# Altium schematic sheet 03 - DDR3.SchDoc("03 - DDR3")
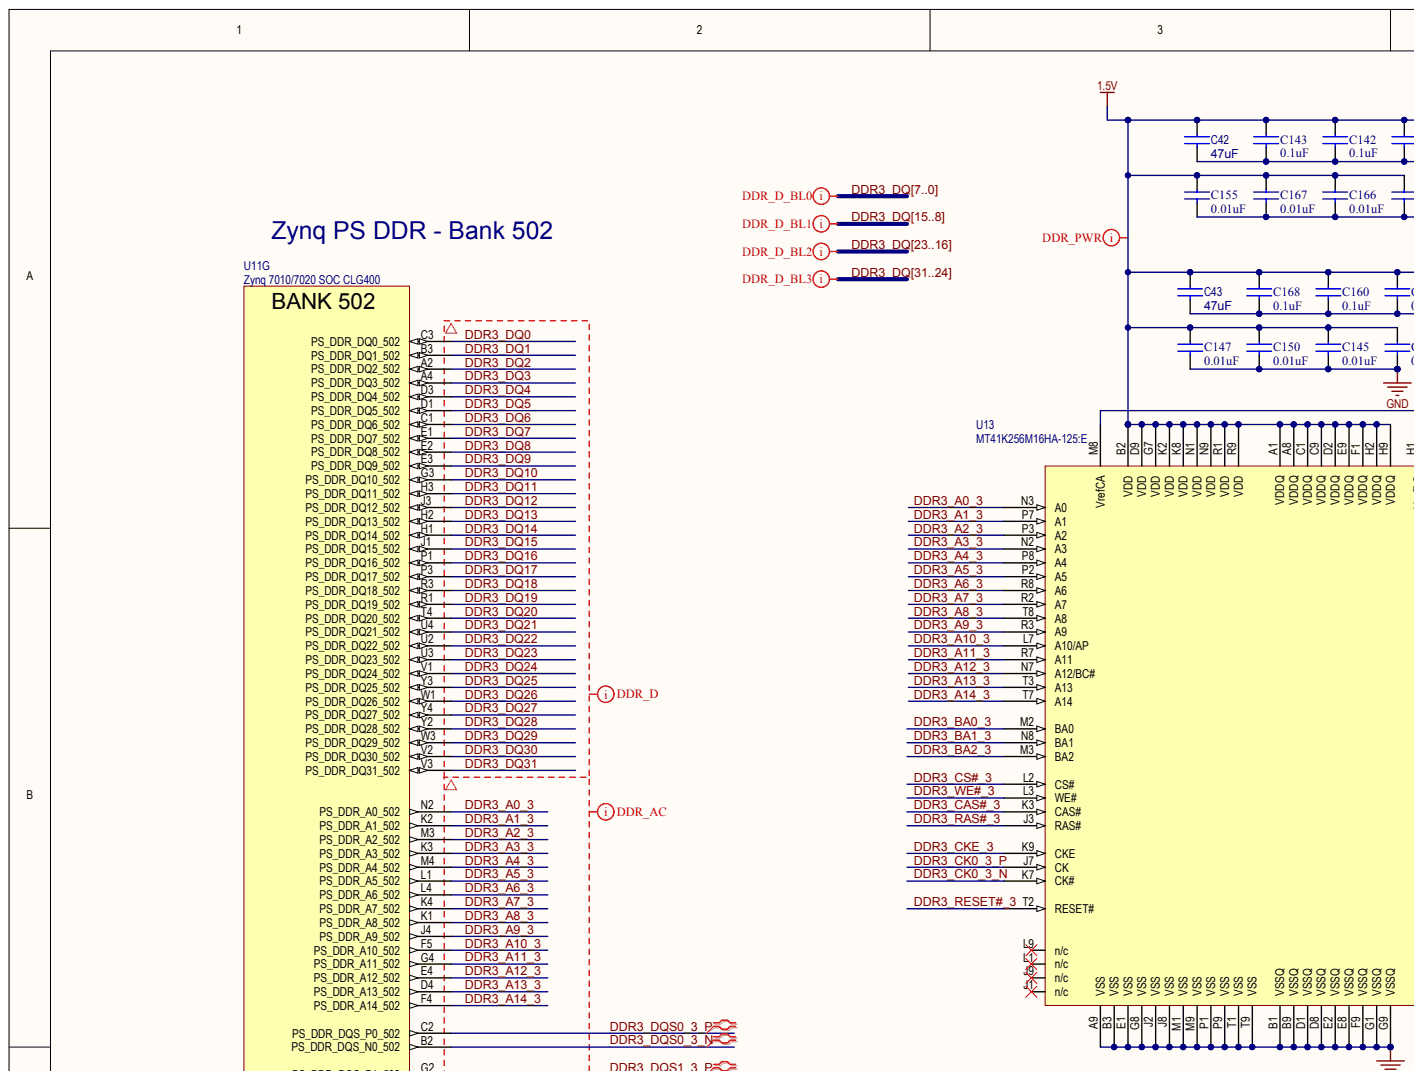
[diagram p0: 03 - DDR3.SchDoc, top left]
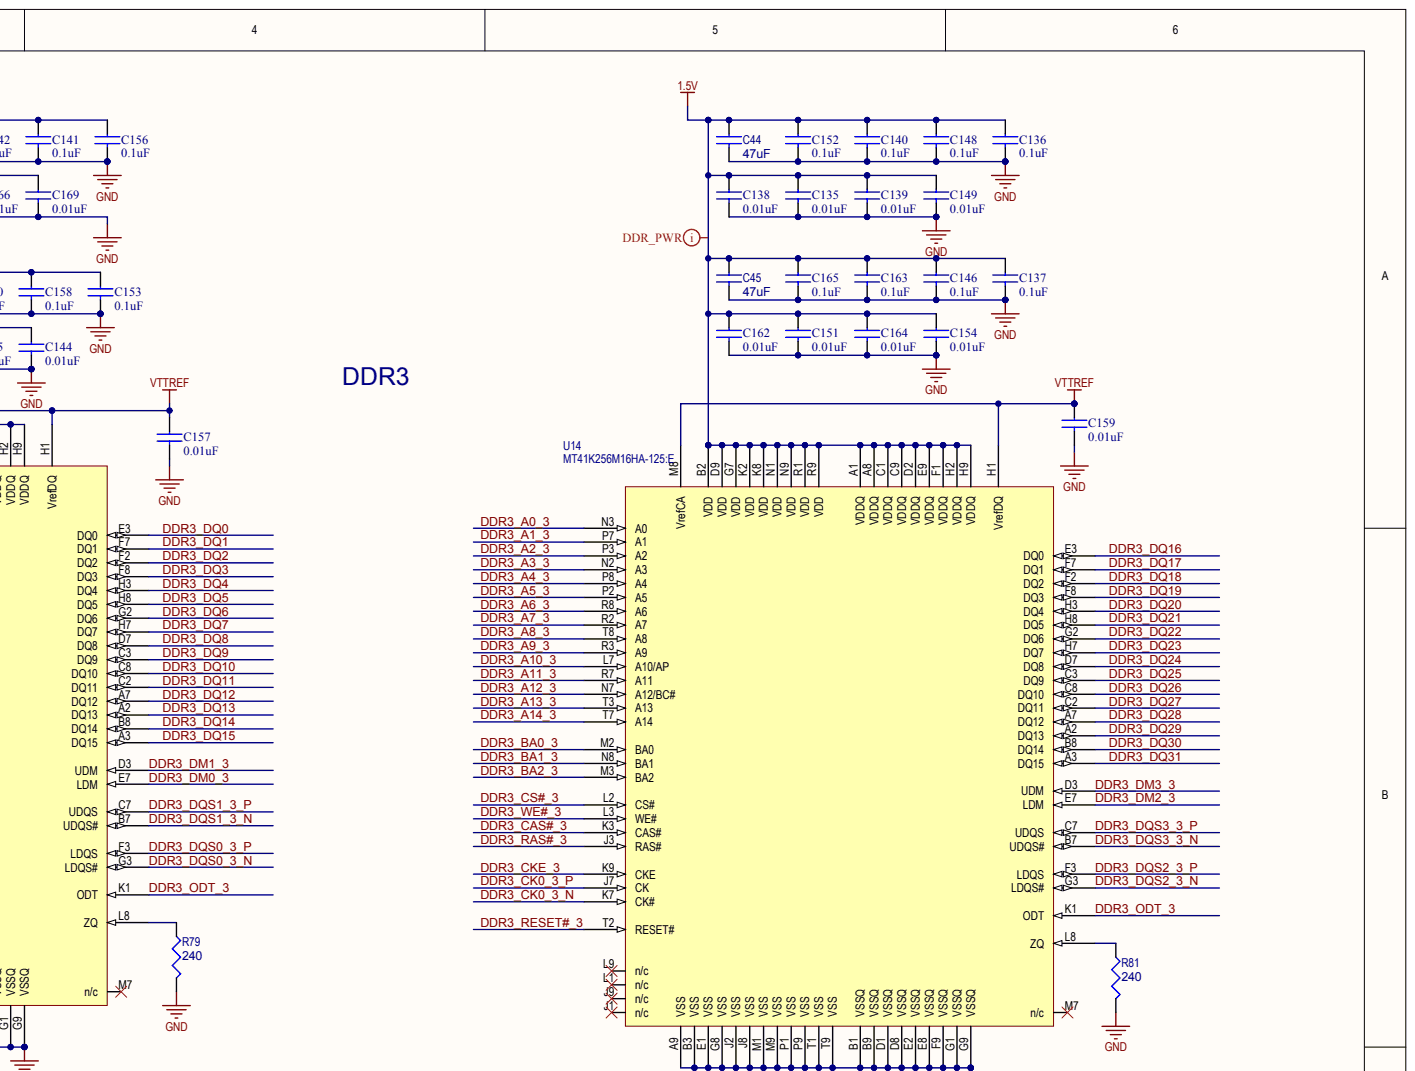
[diagram p1: 03 - DDR3.SchDoc, top right]
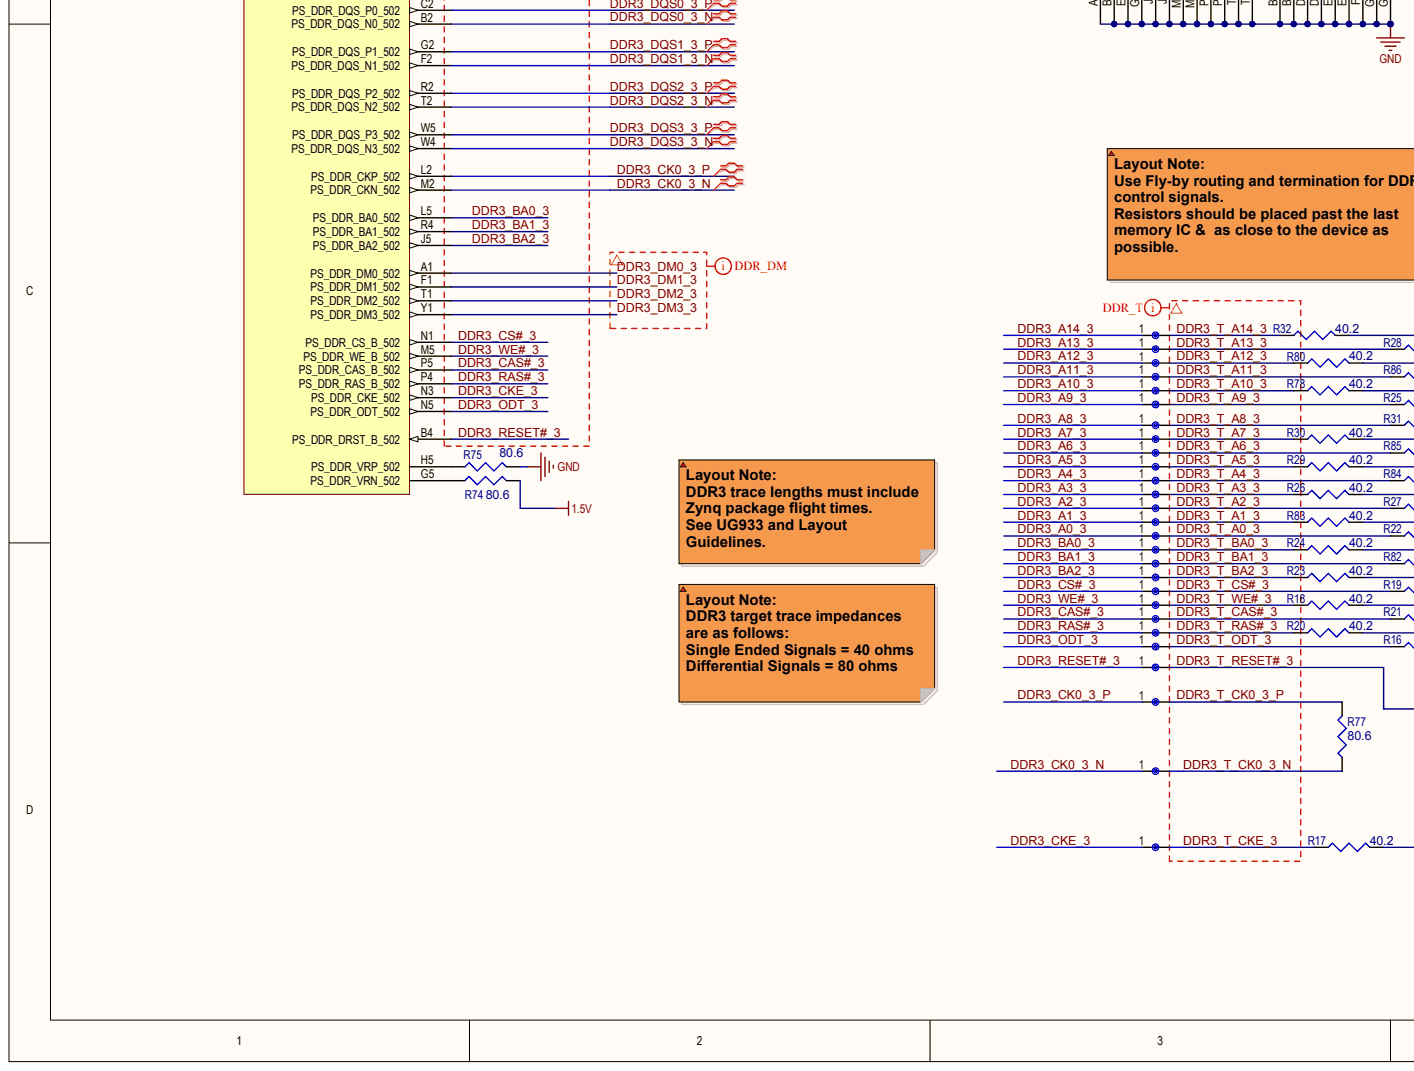
[diagram p2: 03 - DDR3.SchDoc, bottom left]
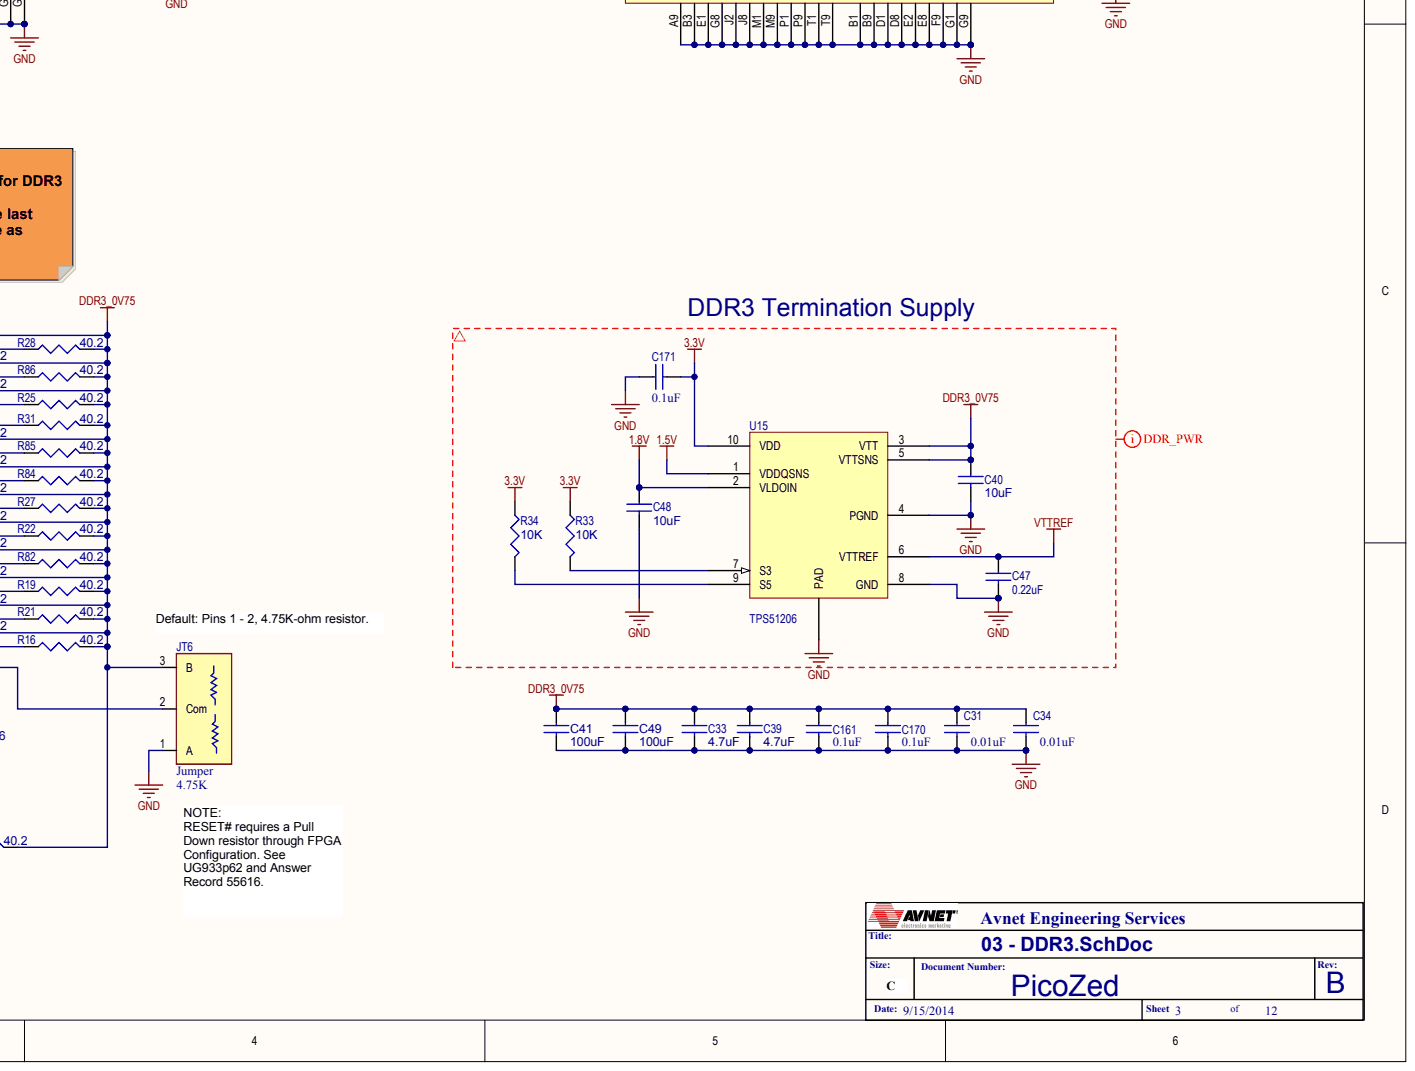
[diagram p3: 03 - DDR3.SchDoc, bottom right]

## Parts
C31  value 0.01uF  (hidden comment: PC003)
C33  4.7uF
C34  value 0.01uF  (hidden comment: PC003)
C39  4.7uF
C40  10uF
C41  100uF
C42  47uF
C43  47uF
C44  47uF
C45  47uF
C47  value 0.22uF  (hidden comment: PC231)
C48  10uF
C49  100uF
C135  value 0.01uF  (hidden comment: PC003)
C136  value 0.1uF  (hidden comment: PC522)
C137  value 0.1uF  (hidden comment: PC522)
C138  value 0.01uF  (hidden comment: PC003)
C139  value 0.01uF  (hidden comment: PC003)
C140  value 0.1uF  (hidden comment: PC522)
C141  value 0.1uF  (hidden comment: PC522)
C142  value 0.1uF  (hidden comment: PC522)
C143  value 0.1uF  (hidden comment: PC522)
C144  value 0.01uF  (hidden comment: PC003)
C145  value 0.01uF  (hidden comment: PC003)
C146  value 0.1uF  (hidden comment: PC522)
C147  value 0.01uF  (hidden comment: PC003)
C148  value 0.1uF  (hidden comment: PC522)
C149  value 0.01uF  (hidden comment: PC003)
C150  value 0.01uF  (hidden comment: PC003)
C151  value 0.01uF  (hidden comment: PC003)
C152  value 0.1uF  (hidden comment: PC522)
C153  value 0.1uF  (hidden comment: PC522)
C154  value 0.01uF  (hidden comment: PC003)
C155  value 0.01uF  (hidden comment: PC003)
C156  value 0.1uF  (hidden comment: PC522)
C157  value 0.01uF  (hidden comment: PC003)
C158  value 0.1uF  (hidden comment: PC522)
C159  value 0.01uF  (hidden comment: PC003)
C160  value 0.1uF  (hidden comment: PC522)
C161  value 0.1uF  (hidden comment: PC522)
C162  value 0.01uF  (hidden comment: PC003)
C163  value 0.1uF  (hidden comment: PC522)
C164  value 0.01uF  (hidden comment: PC003)
C165  value 0.1uF  (hidden comment: PC522)
C166  value 0.01uF  (hidden comment: PC003)
C167  value 0.01uF  (hidden comment: PC003)
C168  value 0.1uF  (hidden comment: PC522)
C169  value 0.01uF  (hidden comment: PC003)
C170  value 0.1uF  (hidden comment: PC522)
C171  value 0.1uF  (hidden comment: PC522)
JT6  value 4.75K  Device: Jumper
NT1  PO030  (value not drawn)
NT2  PO030  (value not drawn)
NT3  PO030  (value not drawn)
NT4  PO030  (value not drawn)
NT5  PO030  (value not drawn)
NT6  PO030  (value not drawn)
NT7  PO030  (value not drawn)
NT8  PO030  (value not drawn)
NT9  PO030  (value not drawn)
NT10  PO030  (value not drawn)
NT11  PO030  (value not drawn)
NT12  PO030  (value not drawn)
NT13  PO030  (value not drawn)
NT14  PO030  (value not drawn)
NT15  PO030  (value not drawn)
NT16  PO030  (value not drawn)
NT17  PO030  (value not drawn)
NT18  PO030  (value not drawn)
NT19  PO030  (value not drawn)
NT20  PO030  (value not drawn)
NT21  PO030  (value not drawn)
NT22  PO030  (value not drawn)
NT23  PO030  (value not drawn)
NT24  PO030  (value not drawn)
NT25  PO030  (value not drawn)
NT26  PO030  (value not drawn)
NT27  PO030  (value not drawn)
R16  40.2
R17  40.2
R18  40.2
R19  40.2
R20  40.2
R21  40.2
R22  40.2
R23  40.2
R24  40.2
R25  40.2
R26  40.2
R27  40.2
R28  40.2
R29  40.2
R30  40.2
R31  40.2
R32  40.2
R33  10K
R34  10K
R74  80.6
R75  80.6
R77  80.6
R78  40.2
R79  240
R80  40.2
R81  240
R82  40.2
R83  40.2
R84  40.2
R85  40.2
R86  40.2
U11  Zynq 7010/7020 SOC CLG400  (part G)
U13  MT41K256M16HA-125:E
U14  MT41K256M16HA-125:E
U15  TPS51206

## Nets
1.5V: C42.1 C43.1 C44.1 C45.1 C135.1 C136.1 C137.1 C138.1 C139.1 C140.1 C141.1 C142.1 C143.1 C144.1 C145.1 C146.1 C147.1 C148.1 C149.1 C150.1 C151.1 C152.1 C153.1 C154.1 C155.1 C156.1 C158.1 C160.1 C162.1 C163.1 C164.1 C165.1 C166.1 C167.1 C168.1 C169.1 R74.2 U13.A1 U13.A8 U13.B2 U13.C1 U13.C9 U13.D2 U13.D9 U13.E9 U13.F1 U13.G7 U13.H2 U13.H9 U13.K2 U13.K8 U13.N1 U13.N9 U13.R1 U13.R9 U14.A1 U14.A8 U14.B2 U14.C1 U14.C9 U14.D2 U14.D9 U14.E9 U14.F1 U14.G7 U14.H2 U14.H9 U14.K2 U14.K8 U14.N1 U14.N9 U14.R1 U14.R9 U15.1
1.8V: C48.1 U15.2
3.3V: C171.2 R33.1 R34.1 U15.10
DDR3_0V75: C31.1 C33.1 C34.1 C39.1 C40.1 C41.1 C49.1 C161.1 C170.1 JT6.3 R16.2 R17.2 R18.2 R19.2 R20.2 R21.2 R22.2 R23.2 R24.2 R25.2 R26.2 R27.2 R28.2 R29.2 R30.2 R31.2 R32.2 R78.2 R80.2 R82.2 R83.2 R84.2 R85.2 R86.2 U15.3 U15.5
GND: C31.2 C33.2 C34.2 C39.2 C40.2 C41.2 C42.2 C43.2 C44.2 C45.2 C47.2 C48.2 C49.2 C135.2 C136.2 C137.2 C138.2 C139.2 C140.2 C141.2 C142.2 C143.2 C144.2 C145.2 C146.2 C147.2 C148.2 C149.2 C150.2 C151.2 C152.2 C153.2 C154.2 C155.2 C156.2 C157.2 C158.2 C159.2 C160.2 C161.2 C162.2 C163.2 C164.2 C165.2 C166.2 C167.2 C168.2 C169.2 C170.2 C171.1 JT6.1 R75.2 R79.2 R81.2 U13.A9 U13.B1 U13.B3 U13.B9 U13.D1 U13.D8 U13.E1 U13.E2 U13.E8 U13.F9 U13.G1 U13.G8 U13.G9 U13.J2 U13.J8 U13.M1 U13.M9 U13.P1 U13.P9 U13.T1 U13.T9 U14.A9 U14.B1 U14.B3 U14.B9 U14.D1 U14.D8 U14.E1 U14.E2 U14.E8 U14.F9 U14.G1 U14.G8 U14.G9 U14.J2 U14.J8 U14.M1 U14.M9 U14.P1 U14.P9 U14.T1 U14.T9 U15.4 U15.8 U15.11
VTTREF: C47.1 C157.1 C159.1 U13.H1 U13.M8 U14.H1 U14.M8 U15.6
DDR3_A0_3: NT10.1 U11.N2 U13.N3 U14.N3  [also labelled DDR3_A0]
DDR3_A1_3: NT13.1 U11.K2 U13.P7 U14.P7  [also labelled DDR3_A1]
DDR3_A2_3: NT14.1 U11.M3 U13.P3 U14.P3  [also labelled DDR3_A2]
DDR3_A3_3: NT15.1 U11.K3 U13.N2 U14.N2  [also labelled DDR3_A3]
DDR3_A4_3: NT21.1 U11.M4 U13.P8 U14.P8  [also labelled DDR3_A4]
DDR3_A5_3: NT17.1 U11.L1 U13.P2 U14.P2  [also labelled DDR3_A5]
DDR3_A6_3: NT22.1 U11.L4 U13.R8 U14.R8  [also labelled DDR3_A6]
DDR3_A7_3: NT18.1 U11.K4 U13.R2 U14.R2  [also labelled DDR3_A7]
DDR3_A8_3: NT23.1 U11.K1 U13.T8 U14.T8  [also labelled DDR3_A8]
DDR3_A9_3: NT19.1 U11.J4 U13.R3 U14.R3  [also labelled DDR3_A9]
DDR3_A10_3: NT16.1 U11.F5 U13.L7 U14.L7  [also labelled DDR3_A10]
DDR3_A11_3: NT24.1 U11.G4 U13.R7 U14.R7  [also labelled DDR3_A11]
DDR3_A12_3: NT25.1 U11.E4 U13.N7 U14.N7  [also labelled DDR3_A12]
DDR3_A13_3: NT26.1 U11.D4 U13.T3 U14.T3  [also labelled DDR3_A13]
DDR3_A14_3: NT27.1 U11.F4 U13.T7 U14.T7  [also labelled DDR3_A14]
DDR3_BA0_3: NT9.1 U11.L5 U13.M2 U14.M2  [also labelled DDR3_BA0]
DDR3_BA1_3: NT20.1 U11.R4 U13.N8 U14.N8  [also labelled DDR3_BA1]
DDR3_BA2_3: NT8.1 U11.J5 U13.M3 U14.M3  [also labelled DDR3_BA2]
DDR3_CAS#_3: NT5.1 U11.P5 U13.K3 U14.K3  [also labelled DDR3_CAS#]
DDR3_CK0_3_N: NT1.1 U11.M2 U13.K7 U14.K7  [also labelled DDR3_CK0_N]
DDR3_CK0_3_P: NT2.1 U11.L2 U13.J7 U14.J7  [also labelled DDR3_CK0_P]
DDR3_CKE_3: NT11.1 U11.N3 U13.K9 U14.K9  [also labelled DDR3_CKE]
DDR3_CS#_3: NT7.1 U11.N1 U13.L2 U14.L2  [also labelled DDR3_CS#]
DDR3_DM0_3: U11.A1 U13.E7  [also labelled DDR3_DM0]
DDR3_DM1_3: U11.F1 U13.D3  [also labelled DDR3_DM1]
DDR3_DM2_3: U11.T1 U14.E7  [also labelled DDR3_DM2]
DDR3_DM3_3: U11.Y1 U14.D3  [also labelled DDR3_DM3]
DDR3_DQ0: U11.C3 U13.E3
DDR3_DQ1: U11.B3 U13.F7
DDR3_DQ2: U11.A2 U13.F2
DDR3_DQ3: U11.A4 U13.F8
DDR3_DQ4: U11.D3 U13.H3
DDR3_DQ5: U11.D1 U13.H8
DDR3_DQ6: U11.C1 U13.G2
DDR3_DQ7: U11.E1 U13.H7
DDR3_DQ8: U11.E2 U13.D7
DDR3_DQ9: U11.E3 U13.C3
DDR3_DQ10: U11.G3 U13.C8
DDR3_DQ11: U11.H3 U13.C2
DDR3_DQ12: U11.J3 U13.A7
DDR3_DQ13: U11.H2 U13.A2
DDR3_DQ14: U11.H1 U13.B8
DDR3_DQ15: U11.J1 U13.A3
DDR3_DQ16: U11.P1 U14.E3
DDR3_DQ17: U11.P3 U14.F7
DDR3_DQ18: U11.R3 U14.F2
DDR3_DQ19: U11.R1 U14.F8
DDR3_DQ20: U11.T4 U14.H3
DDR3_DQ21: U11.U4 U14.H8
DDR3_DQ22: U11.U2 U14.G2
DDR3_DQ23: U11.U3 U14.H7
DDR3_DQ24: U11.V1 U14.D7
DDR3_DQ25: U11.Y3 U14.C3
DDR3_DQ26: U11.W1 U14.C8
DDR3_DQ27: U11.Y4 U14.C2
DDR3_DQ28: U11.Y2 U14.A7
DDR3_DQ29: U11.W3 U14.A2
DDR3_DQ30: U11.V2 U14.B8
DDR3_DQ31: U11.V3 U14.A3
DDR3_DQS0_3_N: U11.B2 U13.G3  [also labelled DDR3_DQS0_N]
DDR3_DQS0_3_P: U11.C2 U13.F3  [also labelled DDR3_DQS0_P]
DDR3_DQS1_3_N: U11.F2 U13.B7  [also labelled DDR3_DQS1_N]
DDR3_DQS1_3_P: U11.G2 U13.C7  [also labelled DDR3_DQS1_P]
DDR3_DQS2_3_N: U11.T2 U14.G3  [also labelled DDR3_DQS2_N]
DDR3_DQS2_3_P: U11.R2 U14.F3  [also labelled DDR3_DQS2_P]
DDR3_DQS3_3_N: U11.W4 U14.B7  [also labelled DDR3_DQS3_N]
DDR3_DQS3_3_P: U11.W5 U14.C7  [also labelled DDR3_DQS3_P]
DDR3_ODT_3: NT4.1 U11.N5 U13.K1 U14.K1  [also labelled DDR3_ODT]
DDR3_RAS#_3: NT3.1 U11.P4 U13.J3 U14.J3  [also labelled DDR3_RAS#]
DDR3_RESET#_3: NT12.1 U11.B4 U13.T2 U14.T2  [also labelled DDR3_RESET#]
DDR3_T_A0_3: NT10.2 R22.1  [also labelled DDR3_T_A0]
DDR3_T_A1_3: NT13.2 R83.1  [also labelled DDR3_T_A1]
DDR3_T_A2_3: NT14.2 R27.1  [also labelled DDR3_T_A2]
DDR3_T_A3_3: NT15.2 R26.1  [also labelled DDR3_T_A3]
DDR3_T_A4_3: NT21.2 R84.1  [also labelled DDR3_T_A4]
DDR3_T_A5_3: NT17.2 R29.1  [also labelled DDR3_T_A5]
DDR3_T_A6_3: NT22.2 R85.1  [also labelled DDR3_T_A6]
DDR3_T_A7_3: NT18.2 R30.1  [also labelled DDR3_T_A7]
DDR3_T_A8_3: NT23.2 R31.1  [also labelled DDR3_T_A8]
DDR3_T_A9_3: NT19.2 R25.1  [also labelled DDR3_T_A9]
DDR3_T_A10_3: NT16.2 R78.1  [also labelled DDR3_T_A10]
DDR3_T_A11_3: NT24.2 R86.1  [also labelled DDR3_T_A11]
DDR3_T_A12_3: NT25.2 R80.1  [also labelled DDR3_T_A12]
DDR3_T_A13_3: NT26.2 R28.1  [also labelled DDR3_T_A13]
DDR3_T_A14_3: NT27.2 R32.1  [also labelled DDR3_T_A14]
DDR3_T_BA0_3: NT9.2 R24.1  [also labelled DDR3_T_BA0]
DDR3_T_BA1_3: NT20.2 R82.1  [also labelled DDR3_T_BA1]
DDR3_T_BA2_3: NT8.2 R23.1  [also labelled DDR3_T_BA2]
DDR3_T_CAS#_3: NT5.2 R21.1  [also labelled DDR3_T_CAS#]
DDR3_T_CK0_3_N: NT1.2 R77.1  [also labelled DDR3_T_CK0_N]
DDR3_T_CK0_3_P: NT2.2 R77.2  [also labelled DDR3_T_CK0_P]
DDR3_T_CKE_3: NT11.2 R17.1  [also labelled DDR3_T_CKE]
DDR3_T_CS#_3: NT7.2 R19.1  [also labelled DDR3_T_CS#]
DDR3_T_ODT_3: NT4.2 R16.1  [also labelled DDR3_T_ODT]
DDR3_T_RAS#_3: NT3.2 R20.1  [also labelled DDR3_T_RAS#]
DDR3_T_RESET#_3: JT6.2 NT12.2  [also labelled DDR3_T_RESET#]
DDR3_T_WE#_3: NT6.2 R18.1  [also labelled DDR3_T_WE#]
DDR3_WE#_3: NT6.1 U11.M5 U13.L3 U14.L3  [also labelled DDR3_WE#]
NetR33_2: R33.2 U15.7
NetR34_2: R34.2 U15.9
NetR74_1: R74.1 U11.G5
NetR75_1: R75.1 U11.H5
NetR79_1: R79.1 U13.L8
NetR81_1: R81.1 U14.L8
N00120:
N00121:
N00122:
N00123:
N00124:

Not connected: U13 J1 J9 L1 L9 M7; U14 J1 J9 L1 L9 M7

## Library data of the parts
C31 C34 C135 C138 C139 C144 C145 C147 C149-C151 C154 C155 C157 C159 C162 C164 C166 C167 C169 = (no symbol name): PartCode: PC; AVLNo: AVPC-0000003; PkgType: SM; Volt: 10V; Tol: 10%; Dielectric: X5R; ESR: CAP; MFG Code: AVX; MFGPN: 0201ZD103KAT2A; AsyTxt: PC003; RoHS: Yes; Status: Preliminary; Device: CAP; ComponentLink1Description: Datasheet; ComponentLink2Description: MFR Website; ComponentLink2URL: www.avx.com; ComponentLink1URL: C:\AVDBLIB\DATASHEETS\AVX_CX5R.pdf
  C135 C138 C139 C149 C151 C154 C159 C162 C164: CLASSNAME: U4-BYP
  C144 C145 C147 C150 C155 C157 C166 C167 C169: CLASSNAME: U3-BYP
C33 C39 = (no symbol name): PartCode: PC; AVLNo: AVPC-0000499; PkgType: SM; AsyTxt: PC499; Volt: 6.3V; Tol: 20%; Dielectric: X5R; MFG Code: AVX; MFGPN: GRM155R60J475ME87D; Power: 0; Device: CAP; Value: 4.7uF; RoHS: Yes; ComponentLink1Description: Datasheet; Availability: 140K-DK; Cost: .46; Size: 1.9; Status: Preliminary; Received: 0; CLASSNAME: DDR_TERM; ComponentLink1URL: C:\AVDBLIB\DATASHEETS\murata_caps_c02e.pdf
C40 C48 = (no symbol name): PartCode: PC; AVLNo: AVPC-0000086; PkgType: SM; AsyTxt: PC086; Volt: 6.3V; Tol: 20%; Dielectric: X5R; MFG Code: AVX; MFGPN: 06036D106MAT2A; Power: 0; Device: CAP; Value: 10uF; RoHS: Yes; ComponentLink1Description: Datasheet; Availability: 2M-AV; Cost: .13; Size: 3.4; Status: Preliminary; Received: 0; CLASSNAME: DDR_TERM; ComponentLink1URL: C:\AVDBLIB\DATASHEETS\AVX_CCOG.pdf
C41 C49 = (no symbol name): PartCode: PC; AVLNo: AVPC-0000209; PkgType: SM; AsyTxt: PC209; Volt: 6.3V; Tol: 20%; Dielectric: X5R; MFG Code: MUR; MFGPN: GRM32EF50J107ZE20K; Power: 0; Device: CAP; Value: 100uF; RoHS: Yes; ComponentLink1Description: Datasheet; Availability: 180K-AV; Cost: .41; Size: 9.11; Status: Preliminary; Received: 0; CLASSNAME: DDR_TERM; ComponentLink1URL: C:\AVDBLIB\DATASHEETS\GRM31CR60J107ME39.pdf
C42-C45 = (no symbol name): PartCode: PC; AVLNo: AVPC-0000521; PkgType: SM; Volt: 6.3V; Tol: 20%; Dielectric: X5R; MFG Code: TYC; MFGPN: JMK212BJ476MG-T; Power: 0; AsyTxt: PC521; Device: CAP; Value: 47uF; RoHS: Yes; ComponentLink1Description: Datasheet; Availability: 100K-AV; Cost: .18; Size: 5.42; Status: Preliminary; Received: 0; ComponentLink1URL: C:\AVDBLIB\DATASHEETS\TYC_Caps.pdf
  C42 C43: CLASSNAME: U3-BYP
  C44 C45: CLASSNAME: U4-BYP
C47 = (no symbol name): PartCode: PC; AVLNo: AVPC-0000231; PkgType: SM; Volt: 6.3V; Tol: 10%; Dielectric: X5R; ESR: CAP; MFG Code: AVX; MFGPN: 04026D224KAT2A; AsyTxt: PC231; RoHS: Yes; Status: Preliminary; Device: CAP; ComponentLink1Description: Datasheet; ComponentLink2Description: MFR Website; ComponentLink2URL: www.avx.com; ComponentLink1URL: C:\AVDBLIB\DATASHEETS\AVX_CX5R.pdf
C136 C137 C140 C146 C148 C152 C161 C163 C165 C170 C171 = (no symbol name): PartCode: PC; MFGPN: 02016D104KAT2A; AVLNo: AVPC-0000522; PkgType: SM; Volt: 10V; Tol: 10%; Dielectric: X5R; Device: CAP; MFG Code: AVX; Status: Preliminary; AsyTxt: PC522; RoHS: Yes; ComponentLink1Description: Datasheet; ComponentLink1URL: C:\AVDBLIB\DATASHEETS\AVX_CCOG.pdf
  C136 C137 C140 C146 C148 C152 C163 C165: CLASSNAME: U4-BYP
C141-C143 C153 C156 C158 C160 C168 = (no symbol name): PartCode: PC; AVLNo: AVPC-0000522; PkgType: SM; Volt: 10V; Tol: 10%; Dielectric: X5R; Device: CAP; MFG Code: AVX; MFGPN: 02016D104KAT2A; AsyTxt: PC522; RoHS: Yes; Status: Preliminary; ComponentLink1Description: Datasheet; CLASSNAME: U3-BYP; ComponentLink1URL: C:\AVDBLIB\DATASHEETS\AVX_CCOG.pdf
JT6 = (no symbol name): PartCode: PO; PkgType: SM; Device Type: 3-pad 4K75 0402; MFG Code: DAL; MFGPN: CRCW04024K75FKED; Note: Place Pads: 1-2; RoHS: Yes; Status: Preliminary; ComponentLink1Description: Datasheet; ComponentLink1URL: C:\AVDBLIB\DATASHEETS\Dale_CRCW.pd
NT1-NT27 = (no symbol name): PartCode: PO; AVLNo: AVPO-0000030; Device: Net Tie; PkgType: SM; MFG Code: None; AsyTxt: PO030; RoHS: Yes; Status: Preliminary; Device Type: 2 Points, 2210; DNP: DNP; MFGPN: None; ComponentLink1Description: Datasheet; CLASSNAME: NT; ComponentLink1URL: C:\AVDBLIB\DATASHEETS\
R16-R31 R78 R80 R82-R86 = (no symbol name): PartCode: PR; PkgType: SM; Watt: 63mW; Tol: 0.01; Device: RES; MFG Code: DAL; MFGPN: CRCW040240R2FKED; Power: 0; AsyTxt: PR092; Watt: 62mW; RoHS: Yes; ComponentLink1Description: Datasheet; Availability: 2M-AV; Cost: .01; Size: 1.9; Status: Preliminary; Received: 0; Value: 40.2; CLASSNAME: DDR_TERMR; ComponentLink1URL: C:\AVDBLIB\DATASHEETS\Dale_CRCW.pd
R32 = (no symbol name): PartCode: PR; AVLNo: AVPR-0000573; PkgType: SM; Watt: 63mW; Tol: 0.01; Device: RES; MFG Code: DAL; MFGPN: CRCW040240R2FKED; Power: 0; AsyTxt: PR092; Watt: 62mW; RoHS: Yes; ComponentLink1Description: Datasheet; Availability: 2M-AV; Cost: .01; Size: 1.9; Status: Preliminary; Received: 0; Value: 40.2; CLASSNAME: DDR_TERMR; ComponentLink1URL: C:\AVDBLIB\DATASHEETS\Dale_CRCW.pd
R33 R34 = (no symbol name): PartCode: PR; AVLNo: AVPR-0000034; PkgType: SM; Watt: 63mW; Tol: 0.01; Device: RES; MFG Code: DAL; MFGPN: CRCW040210K0FKED; Power: 0; AsyTxt: PR034; Watt: 62mW; Value: 10K; RoHS: Yes; ComponentLink1Description: Datasheet; Availability: 2M-AV; Cost: .01; Size: 1.9; Status: Preliminary; Received: 0; ComponentLink1URL: C:\AVDBLIB\DATASHEETS\Dale_CRCW.pd
R74 R75 R77 = (no symbol name): PartCode: PR; AVLNo: AVPR-0000565; PkgType: SM; Watt: 62mW; Tol: 0.01; Device: RES; MFG Code: DAL; MFGPN: CRCW040280R6FKED; Power: 0; AsyTxt: PR565; Value: 80.6; RoHS: Yes; ComponentLink1Description: Datasheet; Availability: 1M-AV; Cost: .01; Size: 1.9; Status: Preliminary; Received: 0; ComponentLink1URL: C:\AVDBLIB\DATASHEETS\Dale_CRCW.pd
  R77: CLASSNAME: DDR_TERMR
R79 R81 = (no symbol name): PartCode: PR; Alt1MFR: NIC; AVLNo: AVPR-0000511; PkgType: SM; Watt: 62mW; Tol: 0.01; Device: RES; MFG Code: DAL; MFGPN: CRCW0402240RFKED; Alt1PN: NRC04F2400TRF; Power: 0; AsyTxt: PR511; Value: 240; RoHS: Yes; ComponentLink1Description: Datasheet; Availability: 1M-AV; Cost: .01; Size: 1.9; Status: Preliminary; Received: 0; ComponentLink1URL: C:\AVDBLIB\DATASHEETS\Dale_CRCW.pd
U11 = (no symbol name): MFGPN: XC7Z010-1CLG400C; MFG Code: XLX; CLASSNAME: BGA
U13 U14 = (no symbol name): MFG Code: MIC; AVLNo: AVIM-0000099; MFGPN: MT41K256M16HA-125:E; CLassname: BGA
U15 = (no symbol name): MFG Code: TIS; MFGPN: TPS51206DSQT; AVLNo: AVIP-0000192; CLASSNAME: FINEPITCH
